# Altium schematic sheet AWS ADC.SchDoc
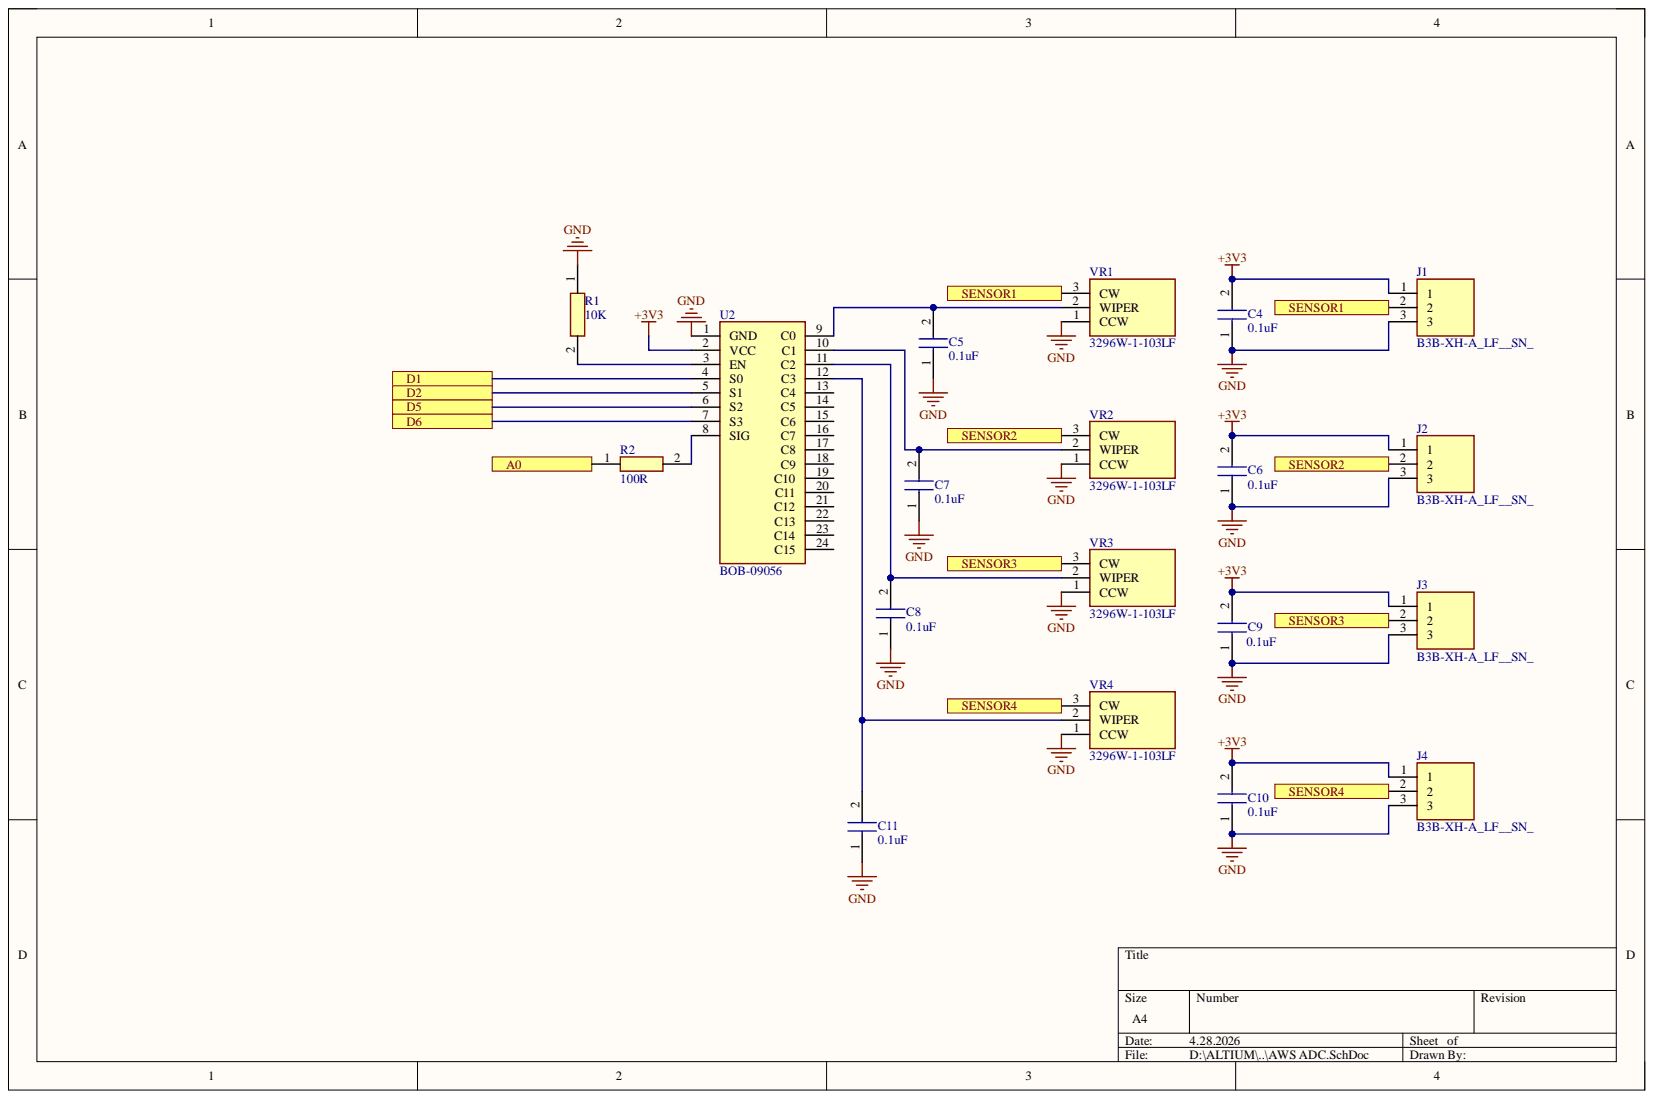
[diagram p0: AWS ADC.SchDoc]

## Parts
C4  0.1uF  [FG18C0G1H101JNT06]
C5  0.1uF  [FG18C0G1H101JNT06]
C6  0.1uF  [FG18C0G1H101JNT06]
C7  0.1uF  [FG18C0G1H101JNT06]
C8  0.1uF  [FG18C0G1H101JNT06]
C9  0.1uF  [FG18C0G1H101JNT06]
C10  0.1uF  [FG18C0G1H101JNT06]
C11  0.1uF  [FG18C0G1H101JNT06]
J1  B3B-XH-A_LF__SN_  [B3BXHALFSN]
J2  B3B-XH-A_LF__SN_  [B3BXHALFSN]
J3  B3B-XH-A_LF__SN_  [B3BXHALFSN]
J4  B3B-XH-A_LF__SN_  [B3BXHALFSN]
R1  10K  [RESC2012X60N]
R2  100R  [RESC2012X60N]
U2  BOB-09056  [BOB09056]
VR1  3296W-1-103LF  [3296W1503LF]
VR2  3296W-1-103LF  [3296W1503LF]
VR3  3296W-1-103LF  [3296W1503LF]
VR4  3296W-1-103LF  [3296W1503LF]

## Ports
A0, D1, D2, D5, D6, SENSOR1, SENSOR2, SENSOR3, SENSOR4

## Nets
+3V3: C4.2 C6.2 C9.2 C10.2 J1.1 J2.1 J3.1 J4.1 U2.2(VCC)
GND: C4.1 C5.1 C6.1 C7.1 C8.1 C9.1 C10.1 C11.1 J1.3 J2.3 J3.3 J4.3 R1.1 U2.1(GND) VR1.1 VR2.1 VR3.1 VR4.1
A0: R2.1 ; port A0
D1: U2.4(S0) ; port D1
D2: U2.5(S1) ; port D2
D5: U2.6(S2) ; port D5
D6: U2.7(S3) ; port D6
SENSOR1: J1.2 VR1.3 ; port SENSOR1
SENSOR2: J2.2 VR2.3 ; port SENSOR2
SENSOR3: J3.2 VR3.3 ; port SENSOR3
SENSOR4: J4.2 VR4.3 ; port SENSOR4
NetC5_2: C5.2 U2.9(C0) VR1.2
NetC7_2: C7.2 U2.10(C1) VR2.2
NetC8_2: C8.2 U2.11(C2) VR3.2
NetC11_2: C11.2 U2.12(C3) VR4.2
NetR1_2: R1.2 U2.3(EN)
NetR2_2: R2.2 U2.8(SIG)

Not connected: U2 13(C4) 14(C5) 15(C6) 16(C7) 17(C8) 18(C9) 19(C10) 20(C11) 21(C12) 22(C13) 23(C14) 24(C15)

## Library data of the parts
C4-C11 = FG18X7R1H104KNT06: Capacitor; Manufacturer_Name: TDK; Arrow Part Number: FG18X7R1H104KNT06; Arrow Price/Stock: https://www.arrow.com/en/products/fg18x7r1h104knt06/tdk; Component Kind: Standard; Datasheet Link: https://product.tdk.com/system/files/dam/doc/product/capacitor/ceramic/lead-mlcc/catalog/leadmlcc_halogenfree_fg_en.pdf; Height: 5.5mm; Manufacturer_Part_Number: FG18X7R1H104KNT06; Mouser Part Number: 810-FG18X7R1H104KNT6; Mouser Price/Stock: https://www.mouser.co.uk/ProductDetail/TDK/FG18X7R1H104KNT06?qs=qf2ddTMq67WA8sH6FratLA%3D%3D
J1-J4 = B3B-XH-A_LF__SN_: Connector; Manufacturer_Name: JST (JAPAN SOLDERLESS TERMINALS); Manufacturer_Part_Number: B3B-XH-A(LF)(SN); Arrow Part Number: B3B-XH-A(LF)(SN); Arrow Price/Stock: https://www.arrow.com/en/products/b3b-xh-a-lf-sn/jst-manufacturing?utm_currency=USD&region=europe; Component Kind: Standard; Datasheet Link: https://datasheet.lcsc.com/szlcsc/1812131720_JST-Sales-America-B3B-XH-A-LF-SN_C144394.pdf; Height: 7mm; Mouser Part Number: 306-B3BXHALFSNP; Mouser Price/Stock: https://www.mouser.co.uk/ProductDetail/JST-Commercial/B3B-XH-ALFSN?qs=cdbOS8ANM9BVkoboCCIEMQ%3D%3D
R1 R2 = RC0805FR-071ML: Resistor; Manufacturer_Name: Yageo Group; Arrow Part Number: RC0805FR-071ML; Arrow Price/Stock: https://www.arrow.com/en/products/rc0805fr-071ml/yageo.html?utm_currency=USD&region=europe; Component Kind: Standard; Datasheet Link: https://www.yageo.com/upload/media/product/productsearch/datasheet/rchip/PYu-RC_Group_51_RoHS_L_11.pdf; Height: 0.6mm; Library Name: SamacSys.SchLib; Manufacturer_Part_Number: RC0805FR-071ML; Mouser Part Number: 603-RC0805FR-071ML; Mouser Price/Stock: https://www.mouser.co.uk/ProductDetail/YAGEO/RC0805FR-071ML?qs=8Y8p%252BasKcI6wvSL4jxV1pQ%3D%3D
U2 = BOB-09056: Integrated Circuit; Manufacturer_Name: SparkFun; Arrow Part Number: BOB-09056; Arrow Price/Stock: https://www.arrow.com/en/products/bob-09056/sparkfun-electronics?region=nac; Component Kind: Standard; Datasheet Link: https://www.sparkfun.com/products/9056; Height: 3mm; Library Name: SamacSys.SchLib; Manufacturer_Part_Number: BOB-09056; Mouser Part Number: 474-BOB-09056; Mouser Price/Stock: https://www.mouser.co.uk/ProductDetail/SparkFun/BOB-09056?qs=WyAARYrbSnY3lmN50Mm%2FiQ%3D%3D
VR1-VR4 = 3296W-1-205LF: Bourns 3296W Series 25-Turn Through Hole Trimmer Resistor with Pin Terminations; Arrow Part Number: 3296W-1-205LF; Arrow Price/Stock: https://www.arrow.com/en/products/3296w-1-205lf/bourns.html?utm_currency=USD&region=europe; Component Kind: Standard; Datasheet Link: https://www.bourns.com/pdfs/3296.pdf; Height: 10.28mm; Library Name: SamacSys.SchLib; Manufacturer_Name: Bourns; Manufacturer_Part_Number: 3296W-1-205LF; Mouser Part Number: 652-3296W-1-205LF; Mouser Price/Stock: https://www.mouser.co.uk/ProductDetail/Bourns/3296W-1-205LF?qs=RWPSkEkPOWy%2F7tQ8oJD5UA%3D%3D
